# Altium schematic sheet LED原理图.SchDoc(LED原理图)
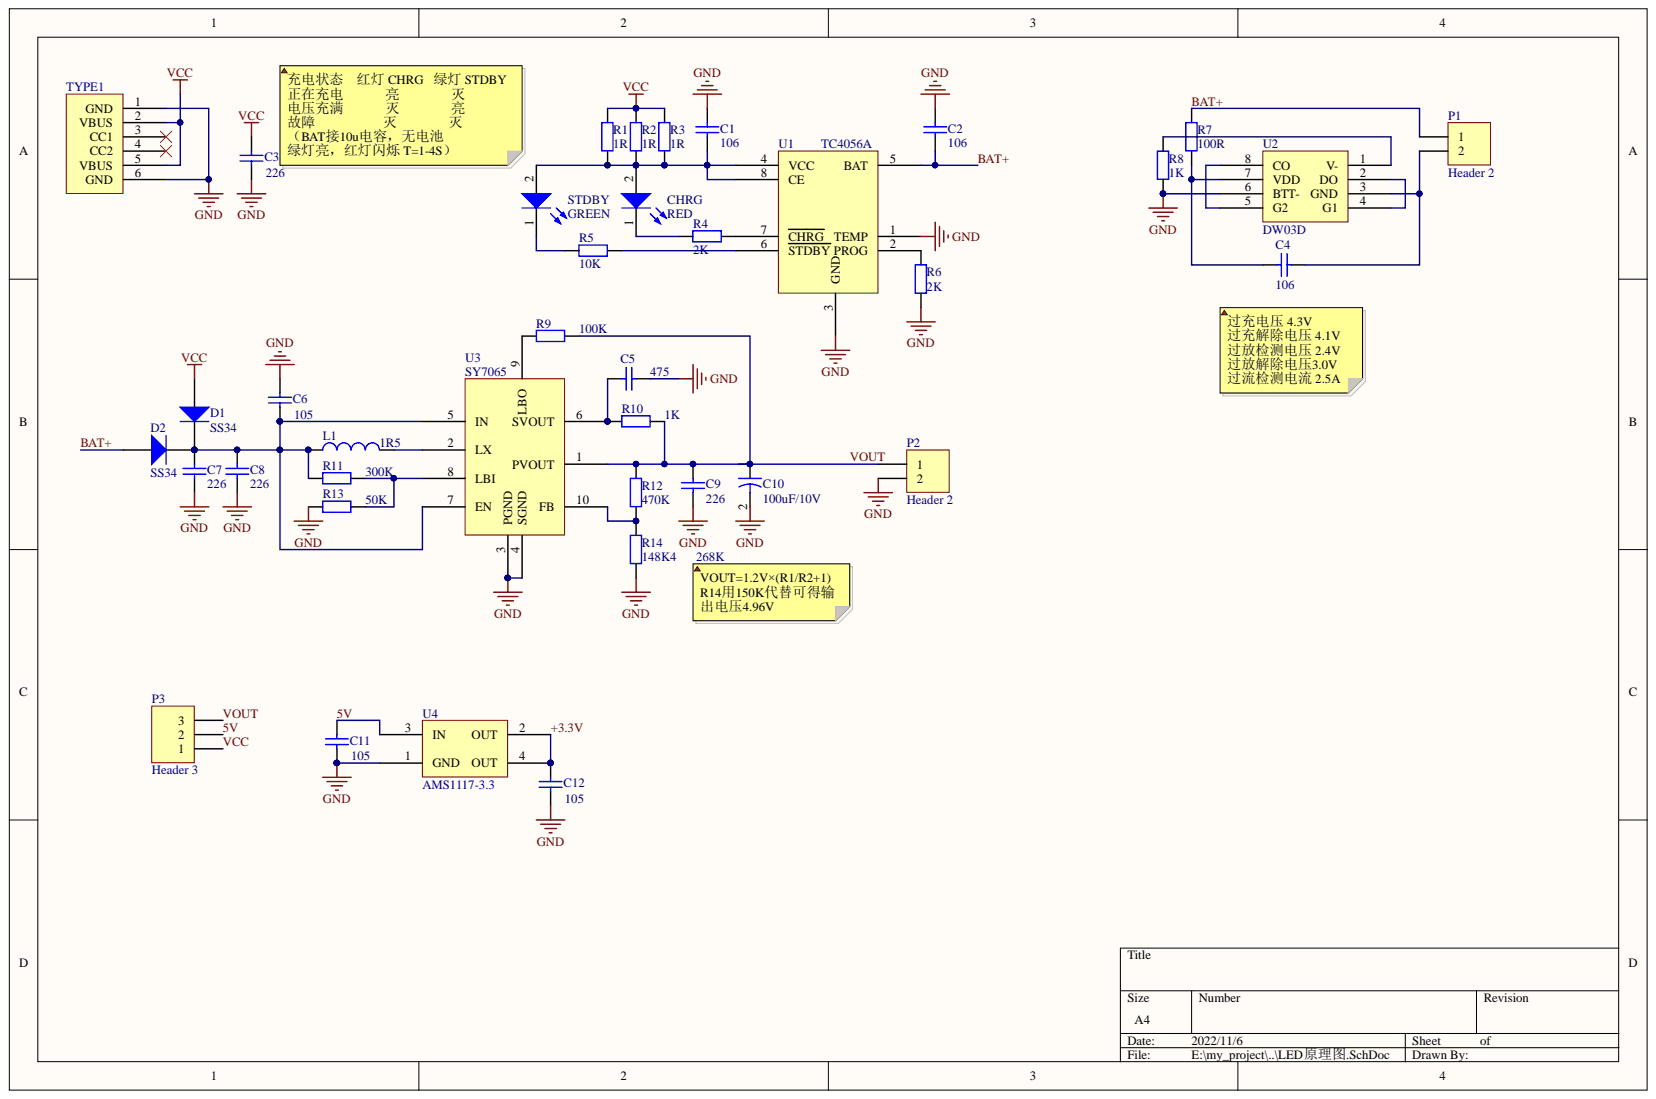
[diagram p0: LED原理图.SchDoc]

## Parts
C1  value 106  [0805C]  (hidden comment: Cap)
C2  value 106  [0805C]  (hidden comment: Cap)
C3  value 226  [0805C]  (hidden comment: Cap)
C4  value 106  [0805C]  (hidden comment: Cap)
C5  value 475  [0805C]  (hidden comment: Cap)
C6  value 105  [0805C]  (hidden comment: Cap)
C7  value 226  [0805C]  (hidden comment: Cap)
C8  value 226  [0805C]  (hidden comment: Cap)
C9  value 226  [0805C]  (hidden comment: Cap)
C10  value 100uF/10V  [C1206]  (hidden comment: Cap2)
C11  value 105  [0805C]  (hidden comment: Cap)
C12  value 105  [0805C]  (hidden comment: Cap)
CHRG  RED  [LED-0805]
D1  SS34  [LC-SMA(DO-214AC)_S2]
D2  SS34  [LC-SMA(DO-214AC)_S2]
L1  value 1R5  [电感SMD]  (hidden comment: Inductor)
P1  Header 2  [XH2.54-2]
P2  Header 2  [XH2.54-2]
P3  Header 3  [HDR1X3]
R1  value 1R  [0805R]  (hidden comment: Res2)
R2  value 1R  [0805R]  (hidden comment: Res2)
R3  value 1R  [0805R]  (hidden comment: Res2)
R4  value 2K  [0805R]  (hidden comment: Res2)
R5  value 10K  [0805R]  (hidden comment: Res2)
R6  value 2K  [0805R]  (hidden comment: Res2)
R7  value 100R  [0805R]  (hidden comment: Res2)
R8  value 1K  [0805R]  (hidden comment: Res2)
R9  value 100K  [0805R]  (hidden comment: Res2)
R10  value 1K  [0805R]  (hidden comment: Res2)
R11  value 300K  [0805R]  (hidden comment: Res2)
R12  value 470K  [0805R]  (hidden comment: Res2)
R13  value 50K  [0805R]  (hidden comment: Res2)
R14  value 148K4      268K  [0805R]  (hidden comment: Res2)
STDBY  GREEN  [LED-0805]
TYPE1  TYPE-CF-6P-RAT-L6.8W  [TYPE-CF-6P-RAT-L6.8W]  (value not drawn)
U1  TC4056A  [SOP-8]
U2  DW03D  [LC-TSSOP-8_3x4.4x065P]
U3  SY7065  [QFN2*2-10]
U4  AMS1117-3.3  [SOT-233]

## Nets
GND: C1.2 C2.2 C3.1 C5.1 C6.2 C7.1 C8.1 C9.2 C10.2 C11.1 C12.1 P2.2 R6.1 R8.2 R13.1 R14.1 TYPE1.1(GND) TYPE1.6(GND) U1.1(TEMP) U1.3(GND) U2.6(BTT-) U3.3(PGND) U3.4(SGND) U4.1(GND)
5V: C11.2 P3.2 U4.3(IN)
+3.3V: C12.2 U4.2(OUT) U4.4(OUT)
BAT+: C2.1 D2.1 P1.1 R7.1 U1.5(BAT)
VCC: C3.2 D1.1 P3.1 R1.1 R2.1 R3.1 TYPE1.2(VBUS) TYPE1.5(VBUS)
VOUT: C9.1 C10.1 P2.1 P3.3 R9.2 R10.2 R12.2 U3.1(PVOUT)
NetC1_1: C1.1 CHRG.2 R1.2 R2.2 R3.2 STDBY.2 U1.4(VCC) U1.8(CE)
NetC4_1: C4.1 P1.2 U2.3(GND)
NetC4_2: C4.2 R7.2 U2.7(VDD)
NetC5_2: C5.2 R10.1 U3.6(SVOUT)
NetC6_1: C6.1 C7.2 C8.2 D1.2 D2.2 L1.1 R11.1 U3.5(IN) U3.7(EN)
NetCHRG_1: CHRG.1 R4.1
NetL1_2: L1.2 U3.2(LX)
NetR4_2: R4.2 U1.7(CHRG)
NetR5_1: R5.1 STDBY.1
NetR5_2: R5.2 U1.6(STDBY)
NetR6_2: R6.2 U1.2(PROG)
NetR8_1: R8.1 U2.1(V-)
NetR9_1: R9.1 U3.9(LBO)
NetR11_2: R11.2 R13.2 U3.8(LBI)
NetR12_1: R12.1 R14.2 U3.10(FB)
NetU2_2: U2.2(DO) U2.4(G1)
NetU2_5: U2.5(G2) U2.8(CO)

Not connected: TYPE1 3(CC1) 4(CC2)

## Library data of the parts
C1-C9 C11 C12 = Cap: Capacitor; Simulation: CAP; Signal Integrity: Cap; Published: 8-Jun-2000; PackageReference: RAD-0.3; PackageDescription: Radial Cap, Thru-Hole; 2 Leads; 0.3 in Pin Spacing; Library Name: Miscellaneous Devices.IntLib; LatestRevisionNote: Re-released for DXP Platform.; LatestRevisionDate: 17-Jul-2002; Component Kind: Standard; Publisher: Altium Limited
C10 = Cap2: Capacitor; Simulation: CAP; Signal Integrity: Cap; Published: 23-Sep-2002; PackageReference: CAPR5-4X5; PackageDescription: Capacitor; 2 Leads; Library Name: Miscellaneous Devices.IntLib; Component Kind: Standard; Publisher: Altium Limited
CHRG STDBY = LED0: Typical INFRARED GaAs LED; Simulation: LED0; Published: 8-Jun-2000; PackageReference: LED-0; PackageDescription: LED; 2 Leads; Library Name: Miscellaneous Devices.IntLib; LatestRevisionNote: Mech Layer 1 removed.; LatestRevisionDate: 08-Jul-2005; Component Kind: Standard; Publisher: Altium Limited
D1 D2 = Diode: Default Diode; Simulation: DIODE; Signal Integrity: Diode; Published: 8-Jun-2000; PackageVersion: Sep-1996; PackageReference: SMC; PackageDescription: DO-214-AB/SMC; 2 C-Bend Leads; Body 7.9 x 5.9 mm, inc. leads (LxW); Library Name: Miscellaneous Devices.IntLib; LatestRevisionNote: Re-released for DXP Platform.; LatestRevisionDate: 17-Jul-2002; Component Kind: Standard; Code_JEDEC: DO-214-AB; Publisher: Altium Limited
L1 = Inductor: Code_IEC: 1005; Simulation: INDUCTOR; Signal Integrity: Inductor; Published: 8-Jun-2000; PackageReference: 0402-A; PackageDescription: Chip Inductor; Library Name: Miscellaneous Devices.IntLib; LatestRevisionNote: IPC-7351 Footprint Added.; LatestRevisionDate: 29-May-2009; Description: Inductor; Component Kind: Standard; Code_JEITA: 0402; Publisher: Altium Limited
P1 P2 = Header 2: Header, 2-Pin; Signal Integrity: Connector; Library Name: Miscellaneous Connectors.IntLib; LatestRevisionNote: Re-released for DXP Platform.; LatestRevisionDate: 17-Jul-2002; Component Kind: Standard; Publisher: Altium Limited
P3 = Header 3: Header, 3-Pin; Signal Integrity: Connector; Library Name: Miscellaneous Connectors.IntLib; LatestRevisionNote: Re-released for DXP Platform.; LatestRevisionDate: 17-Jul-2002; Component Kind: Standard; Publisher: Altium Limited
R1-R14 = Res2: Resistor; Simulation: RESISTOR; Signal Integrity: Res; Published: 8-Jun-2000; PackageReference: AXIAL-0.4; PackageDescription: Axial Device, Thru-Hole; 2 Leads; 0.4 in Pin Spacing; Library Name: Miscellaneous Devices.IntLib; LatestRevisionNote: Re-released for DXP Platform.; LatestRevisionDate: 17-Jul-2002; Component Kind: Standard; Publisher: Altium Limited
TYPE1 = TYPE-CF-6P-RAT-L6.8W: Library Name: 原理图库.SchLib; Component Kind: Standard
U1 = TC4056A: Library Name: 原理图库.SchLib; Component Kind: Standard
U2 = DW03D: Library Name: 原理图库.SchLib; Component Kind: Standard
U3 = SY7065: Library Name: 原理图库.SchLib; Component Kind: Standard
U4 = AMS1117-3.3: Library Name: 原理图库.SchLib; Component Kind: Standard
